# Altium schematic sheet BUTTONS.SchDoc
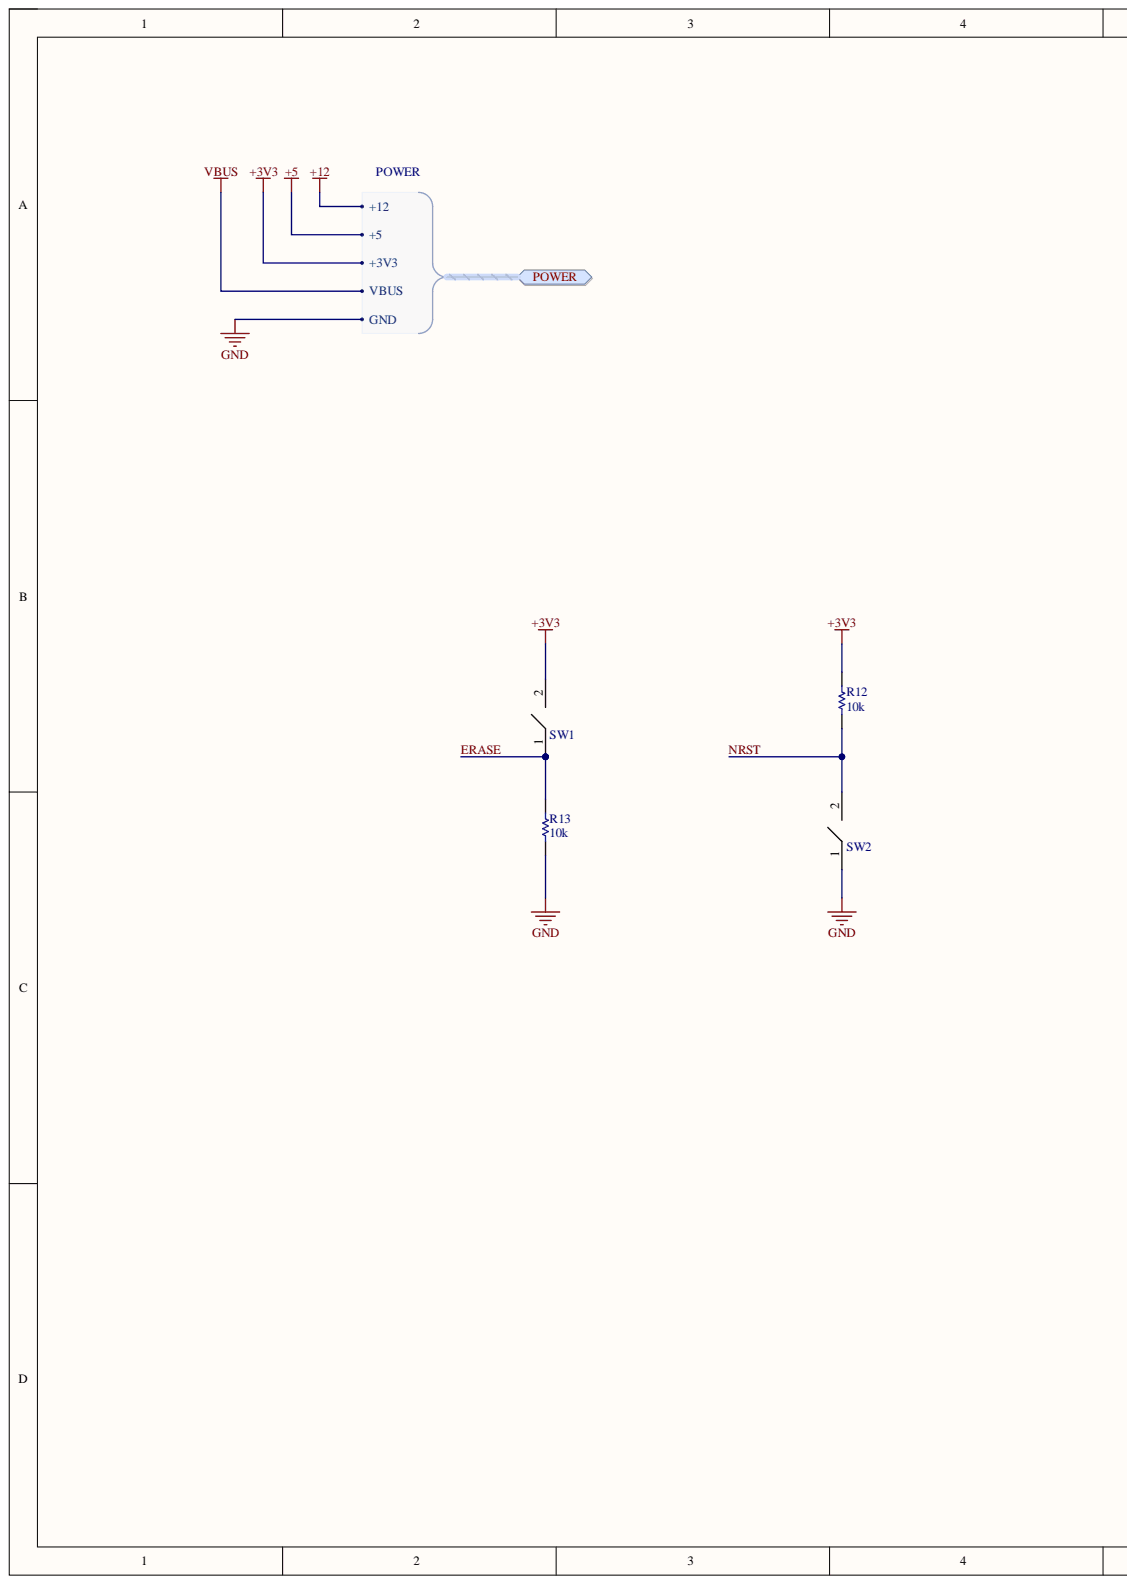
[diagram p0: BUTTONS.SchDoc, left]
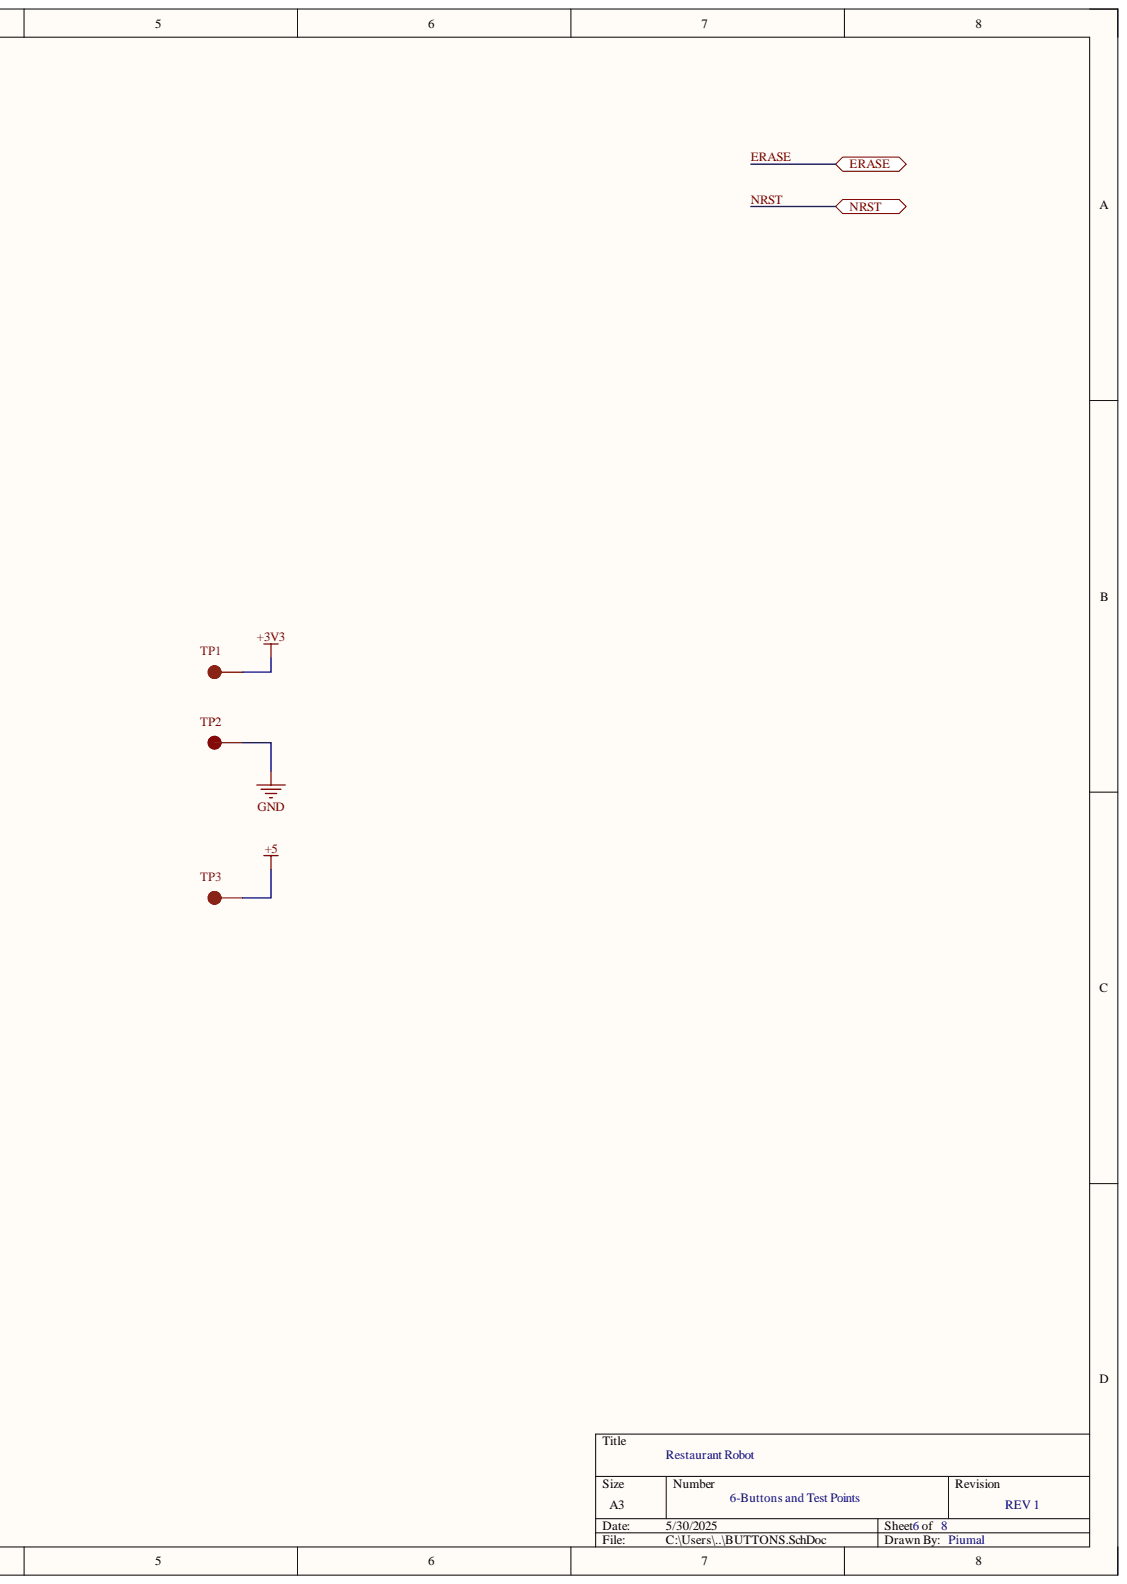
[diagram p1: BUTTONS.SchDoc, right]

## Parts
R12  Resistance: 10k  [RESC2013X60X35ML10T20]  (hidden comment: RC0805JR-0710KL)
R13  Resistance: 10k  [RESC2013X60X35ML10T20]  (hidden comment: RC0805JR-0710KL)
SW1  SWITCH  [PCBComponent_1]  (value not drawn)
SW2  SWITCH  [PCBComponent_1]  (value not drawn)
TP1  5000  [KEYSTONE_5000]  (value not drawn)
TP2  5000  [KEYSTONE_5000]  (value not drawn)
TP3  5000  [KEYSTONE_5000]  (value not drawn)

## Ports
ERASE, NRST, POWER, POWER.+3V3, POWER.+5, POWER.+12, POWER.GND, POWER.VBUS

## Nets
+3V3: R12.2 SW1.2 TP1.TP ; port POWER
+5: TP3.TP ; port POWER
ERASE: R13.2 SW1.1 ; port ERASE
GND: R13.1 SW2.1 TP2.TP ; port POWER
NRST: R12.1 SW2.2 ; port NRST
+12: ; port POWER
VBUS: ; port POWER

## Library data of the parts
R12 R13 = CMP-2000-05025-1: Chip Resistor, 10 KOhm, +/- 5%, 0.125 W, -55 to 155 degC, 0805 (2012 Metric), RoHS, Tape and Reel; Manufacturer: Yageo; Power Rating: 250mW; Product Range: RCSeries; Resistor Case Style: 0805[2012Metric]; Resistor Element Type: ThickFilm; SVHC: ToBeAdvised; Temperature Coefficient: ±100ppm/degC; Voltage Rating: 150V; Automotive Qualification Standard: -; Component Kind: Standard; Datasheet URL: http://www.yageo.ru/pdf/RC0805.pdf; Datasheet Version: REV. 2, 09/2004; Library Name: Altium Content Vault; Manufacturer URL: http://www.yageo.com/; MSL: MSL1-Unlimited; Package Description: 2-Pin Surface Mounted Device, Body 2 x 1.25 mm; Package Reference: 805; Packaging: CutTape; Resistance Tolerance: ±5%
SW1 SW2 = SW: SWITCH TACTILE SPST-NO 0.05A 12V; Component Kind: Standard; Library Name: TS-1011.IntLib
TP1-TP3 = 5000: Test Point Miniature THM H .300 Nylon 46 Insulated Red PhosBronze/Silver | Keystone Electronics 5000; Check_prices: https://www.snapeda.com/parts/5000/Keystone/view-part/?ref=eda; Component Kind: Standard; Library Name: 5000.IntLib; MF: Keystone Electronics; MP: 5000; Package: Test Point Keystone Electronics; SnapEDA_Link: https://www.snapeda.com/parts/5000/Keystone/view-part/?ref=snap
